# Altium schematic sheet [000] Block Diagram.SchDoc
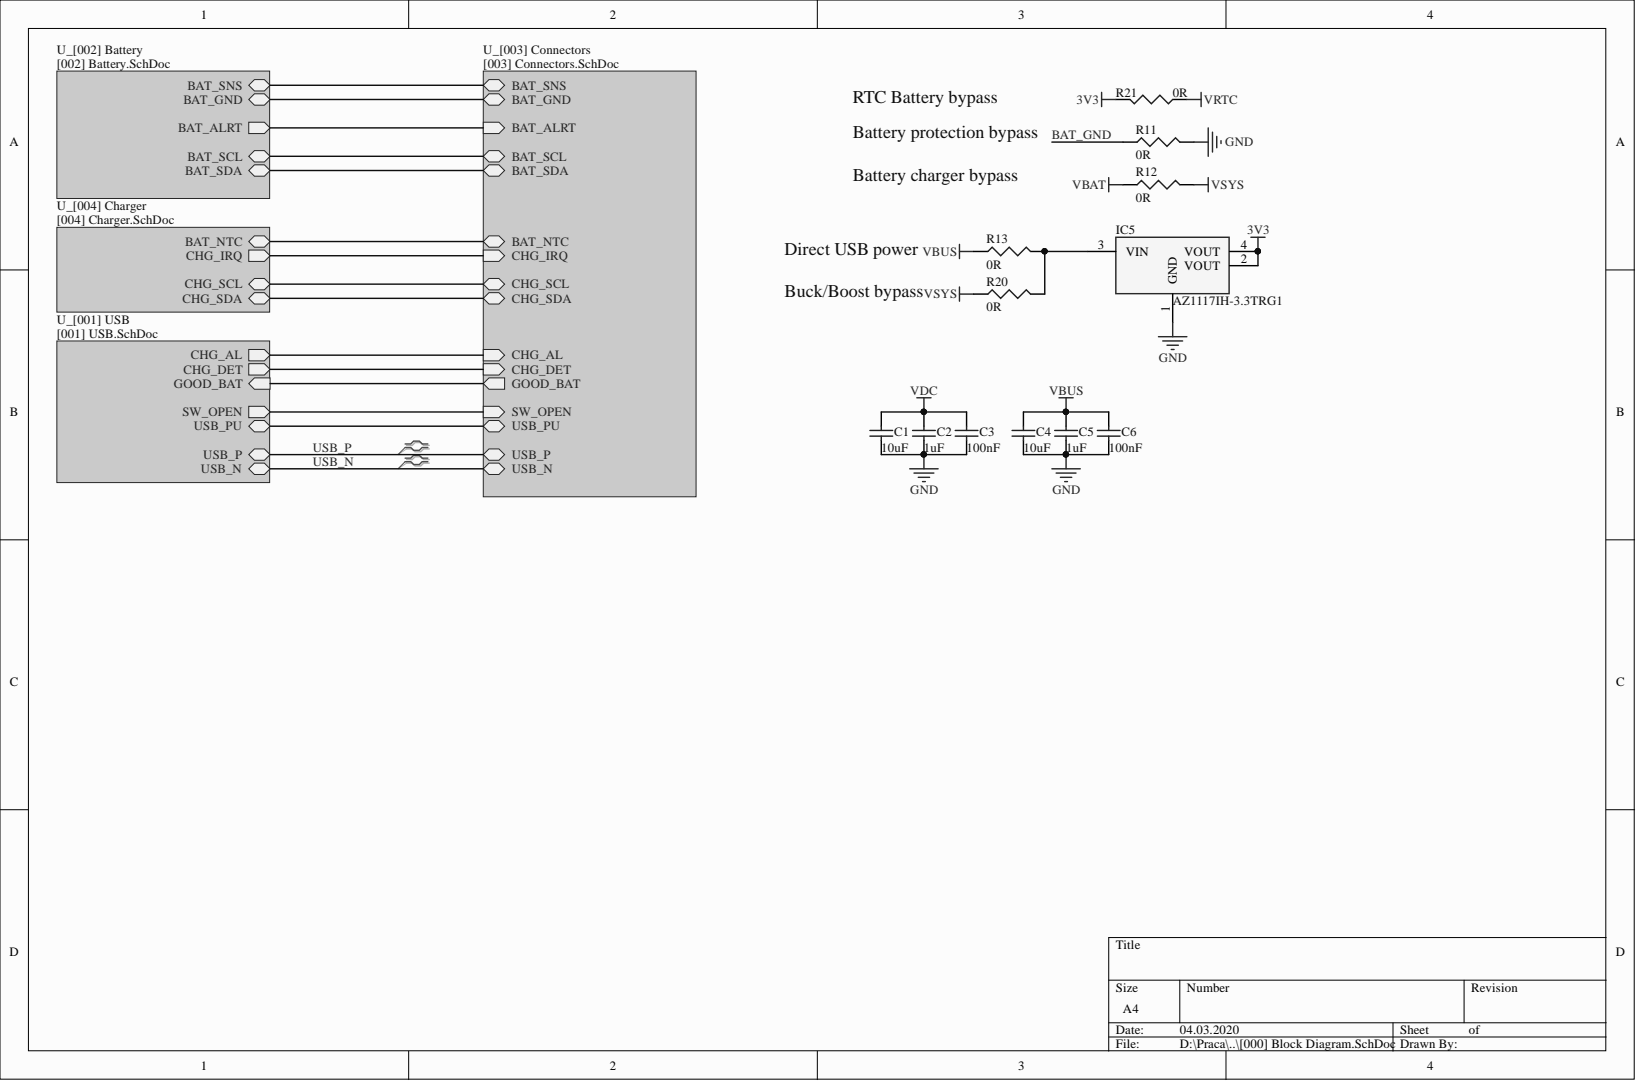
[diagram p0: [000] Block Diagram.SchDoc]

## Parts
C1  value 10uF  [1608[0603]]  (hidden comment: Cap Semi)
C2  value 1uF  [1608[0603]]  (hidden comment: Cap Semi)
C3  value 100nF  [1608[0603]]  (hidden comment: Cap Semi)
C4  value 10uF  [1608[0603]]  (hidden comment: Cap Semi)
C5  value 1uF  [1608[0603]]  (hidden comment: Cap Semi)
C6  value 100nF  [1608[0603]]  (hidden comment: Cap Semi)
IC5  AZ1117IH-3.3TRG1  [SOT230P700X170-4N]
R11  value 0R  [J1-0603]  (hidden comment: Res3)
R12  value 0R  [J1-0603]  (hidden comment: Res3)
R13  value 0R  [J1-0603]  (hidden comment: Res3)
R20  value 0R  [J1-0603]  (hidden comment: Res3)
R21  value 0R  [J1-0603]  (hidden comment: Res3)

## Nets
3V3: IC5.2(VOUT) IC5.4(VOUT) R21.2
GND: C1.1 C2.1 C3.1 C4.1 C5.1 C6.1 IC5.1(GND) R11.2
VBAT: R12.1
VBUS: C4.2 C5.2 C6.2 R13.1
VDC: C1.2 C2.2 C3.2
VRTC: R21.1
VSYS: R12.2 R20.1
BAT_GND: R11.1
NetIC5_3: IC5.3(VIN) R13.2 R20.2
BAT_ALRT:
BAT_NTC:
BAT_SCL:
BAT_SDA:
BAT_SNS:
CHG_AL:
CHG_DET:
CHG_IRQ:
CHG_SCL:
CHG_SDA:
GOOD_BAT:
SW_OPEN:
USB_N:
USB_P:
USB_PU:

## Library data of the parts
C1-C6 = Cap Semi: Capacitor (Semiconductor SIM Model); Component Kind: Standard; LatestRevisionDate: 29-May-2009; LatestRevisionNote: IPC-7351 Footprint Added.; Library Name: Miscellaneous Devices.IntLib; PackageDescription: Chip Capacitor; PackageReference: C1206; Published: 8-Jun-2000; Signal Integrity: Cap Semi; Simulation: CAP; Publisher: Altium Limited
IC5 = AZ1117IH-3.3TRG1: Integrated Circuit; Manufacturer_Name: Diodes Inc.; Component Kind: Standard; Datasheet Link: https://componentsearchengine.com/Datasheets/1/AZ1117IH-3.3TRG1.pdf; Height: 1.7mm; Library Name: SamacSys.SchLib; Manufacturer_Part_Number: AZ1117IH-3.3TRG1; Mouser2 Part Number: 621-AZ1117IH-3.3TRG1; Mouser2 Price/Stock: https://www.mouser.com/Search/Refine.aspx?Keyword=621-AZ1117IH-3.3TRG1
R11-R13 R20 R21 = Res3: Resistor; Component Kind: Standard; LatestRevisionDate: 29-May-2009; LatestRevisionNote: IPC-7351 Footprint Added.; Library Name: Miscellaneous Devices.IntLib; PackageDescription: Chip Resistor; PackageReference: J1-0603; Published: 8-Jun-2000; Signal Integrity: Res; Simulation: RESISTOR; Publisher: Altium Limited
